# Altium schematic sheet 驱动_2.SchDoc
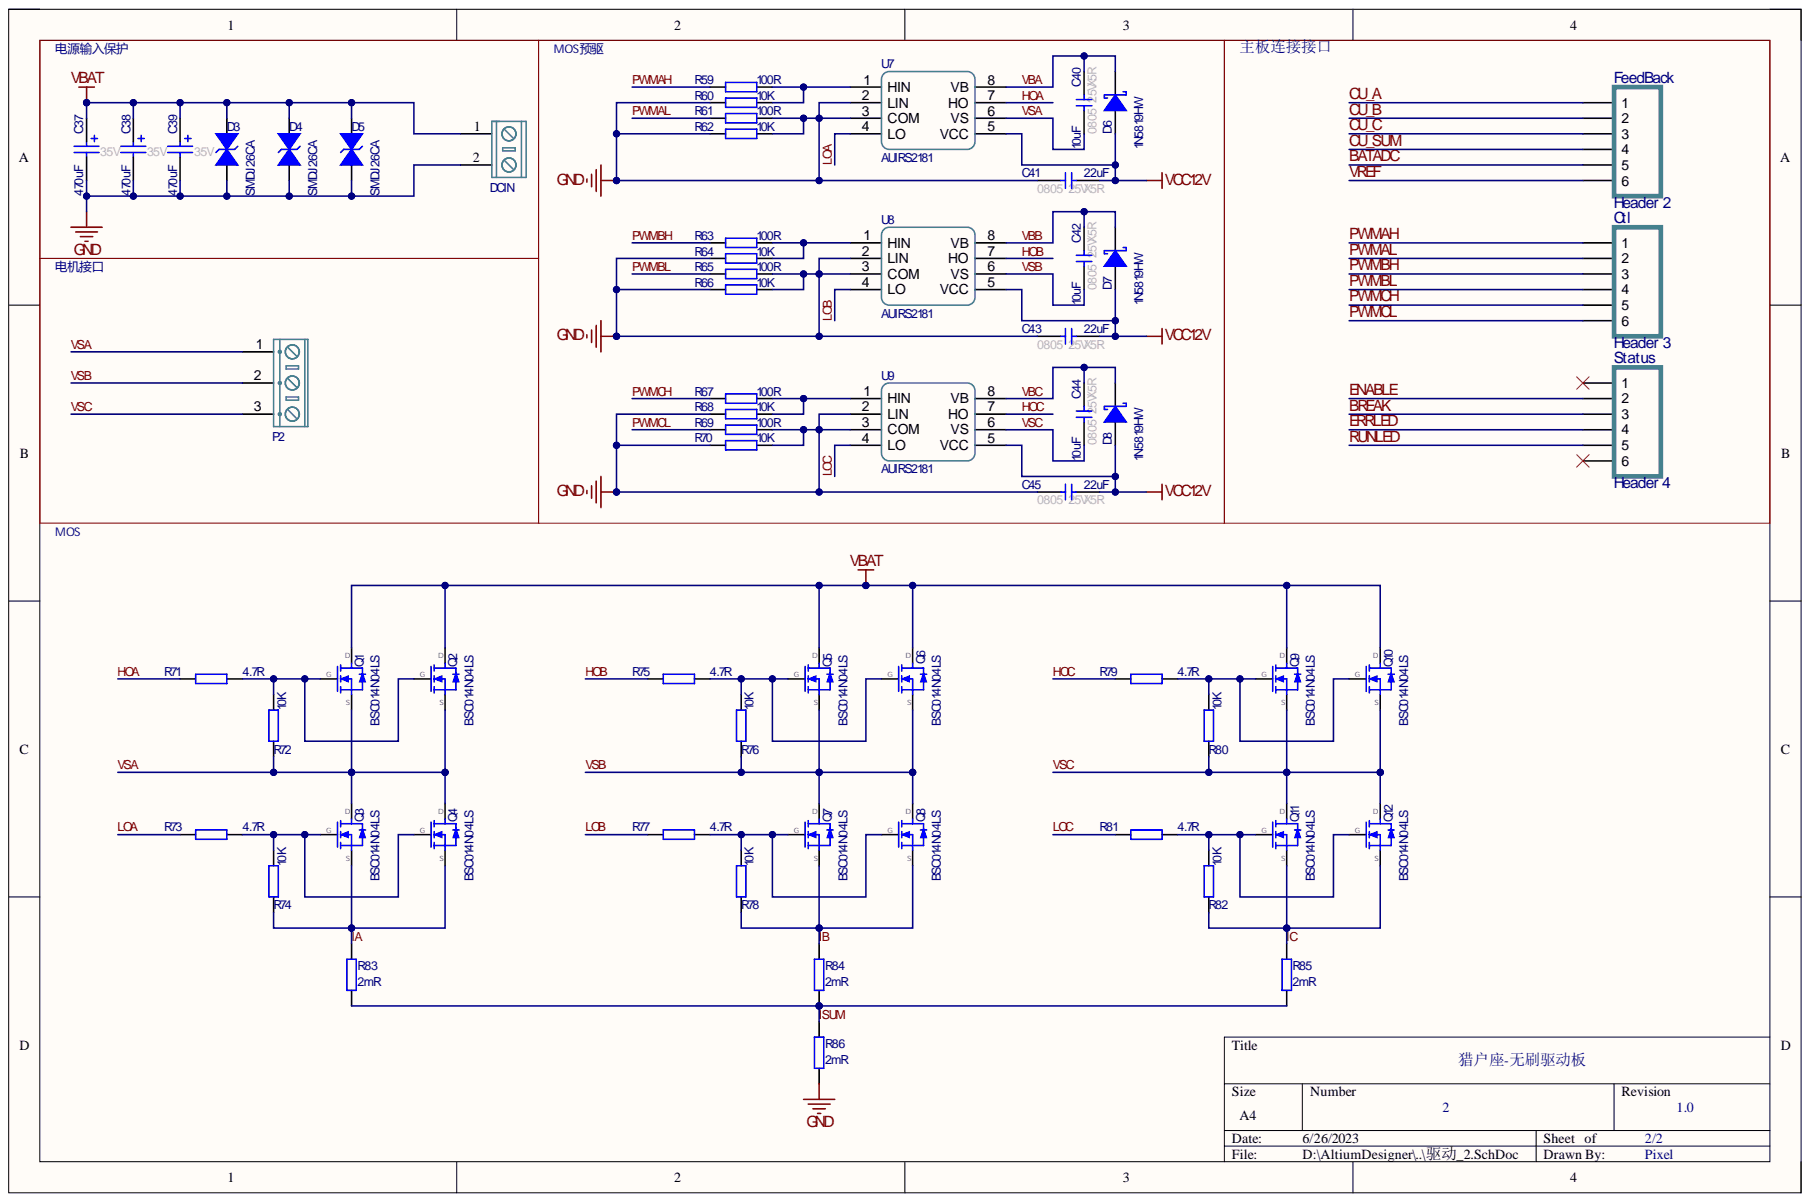
[diagram p0: 驱动_2.SchDoc]

## Parts
C37  value 470uF  Voltage: 35V  [Cap-S-D 3.5-8x14]  (hidden comment: 470uF 35V 聚合物固态)
C38  value 470uF  Voltage: 35V  [Cap-S-D 3.5-8x14]  (hidden comment: 470uF 35V 聚合物固态)
C39  value 470uF  Voltage: 35V  [Cap-S-D 3.5-8x14]  (hidden comment: 470uF 35V 聚合物固态)
C40  value 10uF  [0805]  (hidden comment: 10uF 25V X5R)
C41  value 22uF  [0805]  (hidden comment: 22uF 25V X5R)
C42  value 10uF  [0805]  (hidden comment: 10uF 25V X5R)
C43  value 22uF  [0805]  (hidden comment: 22uF 25V X5R)
C44  value 10uF  [0805]  (hidden comment: 10uF 25V X5R)
C45  value 22uF  [0805]  (hidden comment: 22uF 25V X5R)
Ctl  Header 3  [ZH1.5-6P-SH]
D3  SMDJ26CA  [SMC[DO-214AB]-Bi]
D4  SMDJ26CA  [SMC[DO-214AB]-Bi]
D5  SMDJ26CA  [SMC[DO-214AB]-Bi]
D6  1N5819HW  [SOD-123-L]
D7  1N5819HW  [SOD-123-L]
D8  1N5819HW  [SOD-123-L]
DCIN  KF/WJ/DG 30x connecter  [KF/WJ/DG 301-2p-5.0mm]  (value not drawn)
FeedBack  Header 2  [ZH1.5-6P-SH]
P2  KF/WJ/DG 30x connecter  [KF/WJ/DG 301-3p-5.0mm]  (value not drawn)
Q1  BSC014N04LS  [TDSON-8]
Q2  BSC014N04LS  [TDSON-8]
Q3  BSC014N04LS  [TDSON-8]
Q4  BSC014N04LS  [TDSON-8]
Q5  BSC014N04LS  [TDSON-8]
Q6  BSC014N04LS  [TDSON-8]
Q7  BSC014N04LS  [TDSON-8]
Q8  BSC014N04LS  [TDSON-8]
Q9  BSC014N04LS  [TDSON-8]
Q10  BSC014N04LS  [TDSON-8]
Q11  BSC014N04LS  [TDSON-8]
Q12  BSC014N04LS  [TDSON-8]
R59  100R ±1%  value 100R  [RESC1005[0402]S-N]
R60  10K ±1%  value 10K  [RESC1005[0402]S-N]
R61  100R ±1%  value 100R  [RESC1005[0402]S-N]
R62  10K ±1%  value 10K  [RESC1005[0402]S-N]
R63  100R ±1%  value 100R  [RESC1005[0402]S-N]
R64  10K ±1%  value 10K  [RESC1005[0402]S-N]
R65  100R ±1%  value 100R  [RESC1005[0402]S-N]
R66  10K ±1%  value 10K  [RESC1005[0402]S-N]
R67  100R ±1%  value 100R  [RESC1005[0402]S-N]
R68  10K ±1%  value 10K  [RESC1005[0402]S-N]
R69  100R ±1%  value 100R  [RESC1005[0402]S-N]
R70  10K ±1%  value 10K  [RESC1005[0402]S-N]
R71  value 4.7R  [RESC1608[0603]S-N]  (hidden comment: 4.7R ±1%)
R72  value 10K  [RESC1005[0402]S-N]  (hidden comment: 10K ±1%)
R73  value 4.7R  [RESC1608[0603]S-N]  (hidden comment: 4.7R ±1%)
R74  value 10K  [RESC1005[0402]S-N]  (hidden comment: 10K ±1%)
R75  value 4.7R  [RESC1608[0603]S-N]  (hidden comment: 4.7R ±1%)
R76  value 10K  [RESC1005[0402]S-N]  (hidden comment: 10K ±1%)
R77  value 4.7R  [RESC1608[0603]S-N]  (hidden comment: 4.7R ±1%)
R78  value 10K  [RESC1005[0402]S-N]  (hidden comment: 10K ±1%)
R79  value 4.7R  [RESC1608[0603]S-N]  (hidden comment: 4.7R ±1%)
R80  value 10K  [RESC1005[0402]S-N]  (hidden comment: 10K ±1%)
R81  value 4.7R  [RESC1608[0603]S-N]  (hidden comment: 4.7R ±1%)
R82  value 10K  [RESC1005[0402]S-N]  (hidden comment: 10K ±1%)
R83  value 2mR  [RESC6332[2512]S]  (hidden comment: 2mR ±1%)
R84  value 2mR  [RESC6332[2512]S]  (hidden comment: 2mR ±1%)
R85  value 2mR  [RESC6332[2512]S]  (hidden comment: 2mR ±1%)
R86  value 2mR  [RESC6332[2512]S]  (hidden comment: 2mR ±1%)
Status  Header 4  [ZH1.5-6P-SH]
U7  AUIRS2181  [SOP-8]
U8  AUIRS2181  [SOP-8]
U9  AUIRS2181  [SOP-8]

## Nets
GND: C37.2 C38.2 C39.2 C41.1 C43.1 C45.1 D3.K D4.K D5.K DCIN.2 R60.1 R61.2 R62.1 R62.2 R64.1 R65.2 R66.1 R66.2 R68.1 R69.2 R70.1 R70.2 R86.1 U7.2(LIN) U7.3(COM) U8.2(LIN) U8.3(COM) U9.2(LIN) U9.3(COM)
VBAT: C37.1 C38.1 C39.1 D3.A D4.A D5.A DCIN.1 Q1.1 Q2.1 Q5.1 Q6.1 Q9.1 Q10.1
VCC12V: C41.2 C43.2 C45.2 D6.A D7.A D8.A U7.5(VCC) U8.5(VCC) U9.5(VCC)
BATADC: FeedBack.5
BREAK: Status.3
CU_A: FeedBack.1
CU_B: FeedBack.2
CU_C: FeedBack.3
CU_SUM: FeedBack.4
ENABLE: Status.2
ERRLED: Status.4
HOA: R71.1 U7.7(HO)
HOB: R75.1 U8.7(HO)
HOC: R79.1 U9.7(HO)
IA: Q3.3 Q4.3 R74.1 R83.2
IB: Q7.3 Q8.3 R78.1 R84.2
IC: Q11.3 Q12.3 R82.1 R85.2
ISUM: R83.1 R84.1 R85.1 R86.2
LOA: R73.1 U7.4(LO)
LOB: R77.1 U8.4(LO)
LOC: R81.1 U9.4(LO)
PWMAH: Ctl.1 R59.1
PWMAL: Ctl.2 R61.1
PWMBH: Ctl.3 R63.1
PWMBL: Ctl.4 R65.1
PWMCH: Ctl.5 R67.1
PWMCL: Ctl.6 R69.1
RUNLED: Status.5
VBA: C40.2 D6.K U7.8(VB)
VBB: C42.2 D7.K U8.8(VB)
VBC: C44.2 D8.K U9.8(VB)
VREF: FeedBack.6
VSA: C40.1 P2.1 Q1.3 Q2.3 Q3.1 Q4.1 R72.1 U7.6(VS)
VSB: C42.1 P2.2 Q5.3 Q6.3 Q7.1 Q8.1 R76.1 U8.6(VS)
VSC: C44.1 P2.3 Q9.3 Q10.3 Q11.1 Q12.1 R80.1 U9.6(VS)
NetQ1_2: Q1.2 Q2.2 R71.2 R72.2
NetQ3_2: Q3.2 Q4.2 R73.2 R74.2
NetQ5_2: Q5.2 Q6.2 R75.2 R76.2
NetQ7_2: Q7.2 Q8.2 R77.2 R78.2
NetQ9_2: Q9.2 Q10.2 R79.2 R80.2
NetQ11_2: Q11.2 Q12.2 R81.2 R82.2
NetR59_2: R59.2 R60.2 U7.1(HIN)
NetR63_2: R63.2 R64.2 U8.1(HIN)
NetR67_2: R67.2 R68.2 U9.1(HIN)

Not connected: Status 1 6

## Library data of the parts
C37-C39 = S-D 470uF 35V 8x14: 直插固态电容 470uF 35V 8x14; Component Kind: Standard; Revision: V1.0; Type: 聚合物固态; Library Name: 无刷驱动-Infineon-AUIRS2181S-GTM-6S.SchLib
C40 C42 C44 = 10uF 0805 25V X5R: 贴片瓷片电容; MLCC; 0805(2012);; Component Kind: Standard; Revision: V1.0; Type: X5R; Voltage: 25V; Library Name: 无刷驱动-Infineon-AUIRS2181S-GTM-6S.SchLib
C41 C43 C45 = 22uF 0805 25V X5R: 贴片瓷片电容; MLCC; 0805(2012);; Component Kind: Standard; Revision: V1.0; Type: X5R; Voltage: 25V; Library Name: 无刷驱动-Infineon-AUIRS2181S-GTM-6S.SchLib
Ctl FeedBack Status = Header 6: Header, 6-Pin; Component Kind: Standard; LatestRevisionDate: 17-Jul-2002; LatestRevisionNote: Re-released for DXP Platform.; Price(RMB): 0.00; Signal Integrity: Connector; Library Name: 无刷驱动-Infineon-AUIRS2181S-GTM-6S.SchLib; Publisher: Altium Limited
D3-D5 = Diode TVS Bi-Dir: TRANSIENT VOLTAGE  SUPPRESSOR DIODE; Imax: 500mA; Component Kind: Standard; Price(RMB): 0.000; Published: 8-Jun-2000; Library Name: 无刷驱动-Infineon-AUIRS2181S-GTM-6S.SchLib; Publisher: Altium Limited
D6-D8 = 1N5819HW: 1N5819HW 肖特基二极管; Component Kind: Standard; Revision: V1.0; Value: 1N5819HW; Library Name: 无刷驱动-Infineon-AUIRS2181S-GTM-6S.SchLib
DCIN = KF/WJ/DG 30x: 接线端子 2Pin; Component Kind: Standard; Library Name: 无刷驱动-Infineon-AUIRS2181S-GTM-6S.SchLib
P2 = KF/WJ/DG 30x-3P: 接线端子 3Pin; Component Kind: Standard; Library Name: 无刷驱动-Infineon-AUIRS2181S-GTM-6S.SchLib
Q1-Q12 = BSC014N04LS: 英飞凌 N沟 MOS; Component Kind: Standard; Library Name: 无刷驱动-Infineon-AUIRS2181S-GTM-6S.SchLib
R59 R61 R63 R65 R67 R69 = Res-Chip_5: 贴片电阻; Power: 1/8W; Component Kind: Standard; Revision: V1.0; Tolerance: ±1%; Library Name: 无刷驱动-Infineon-AUIRS2181S-GTM-6S.SchLib
R60 R62 R64 R66 R68 R70 R72 R74 R76 R78 R80 R82 = Res-Chip_1: 贴片电阻; Power: 1/8W; Component Kind: Standard; Revision: V1.0; Tolerance: ±1%; Library Name: 无刷驱动-Infineon-AUIRS2181S-GTM-6S.SchLib
R71 R73 R75 R77 R79 R81 = Res-Chip_16: 贴片电阻; Power: 1/8W; Component Kind: Standard; Revision: V1.0; Tolerance: ±1%; Library Name: 无刷驱动-Infineon-AUIRS2181S-GTM-6S.SchLib
R83-R86 = Res-Chip_17: 贴片电阻; Power: 1/8W; Component Kind: Standard; Revision: V1.0; Tolerance: ±1%; Library Name: 无刷驱动-Infineon-AUIRS2181S-GTM-6S.SchLib
U7-U9 = AUIRS2181: Component Kind: Standard; Description: AUIRS2181; Library Name: 无刷驱动-Infineon-AUIRS2181S-GTM-6S.SchLib
